# Altium schematic sheet Center Console - IO Expander.SchDoc("Center Console - IO Expander")
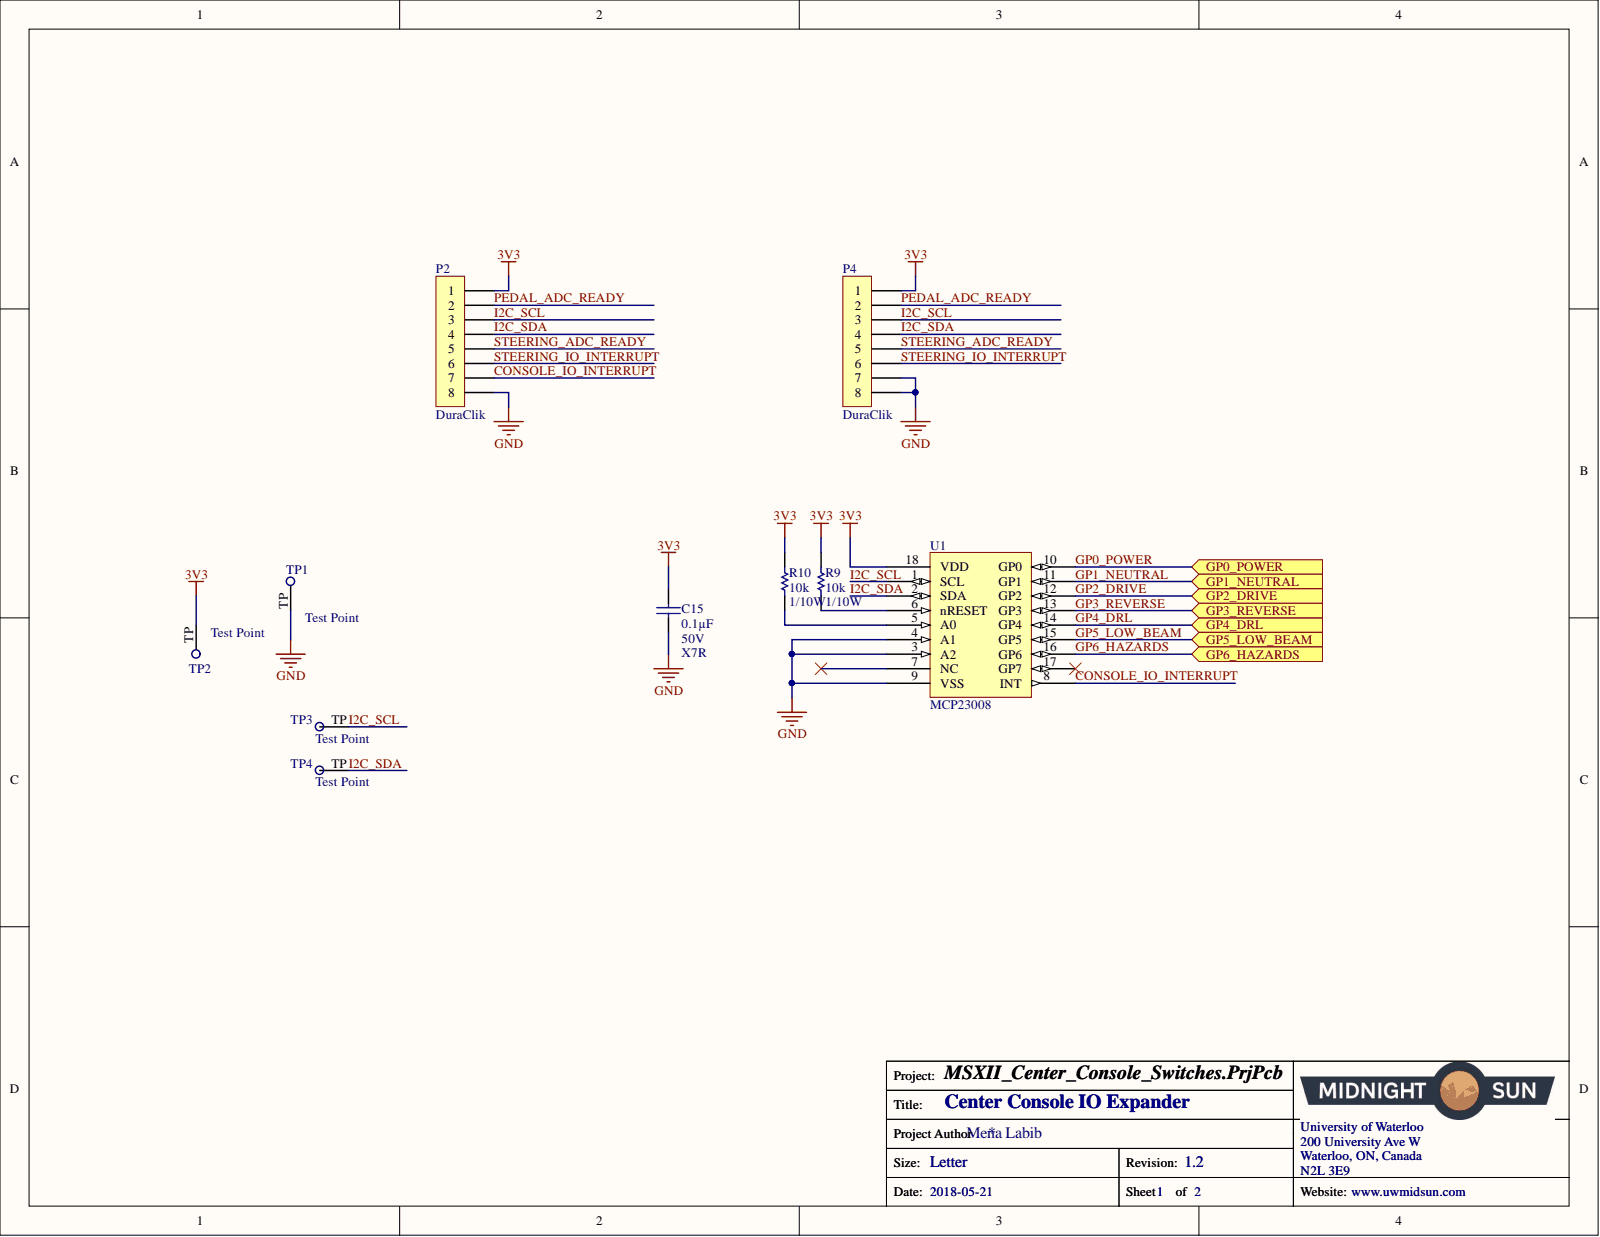
[diagram p0: Center Console - IO Expander.SchDoc]

## Parts
C15  Voltage: 50V  Temperature Coefficient: X7R  Capacitance: 0.1µF  [CAP, 0603]  (hidden comment: CAP CER 0.1UF 50V 10% X7R 0603)
P2  Series: DuraClik  [CONN, 8POS Dura-Clik]  (hidden comment: CONN 8POS DURA-CLIK 0.079")
P4  Series: DuraClik  [CONN, 8POS Dura-Clik]  (hidden comment: CONN 8POS DURA-CLIK 0.079")
R9  Resistance: 10k  Power: 1/10W  [RES, 0603]  (hidden comment: RES 10K OHM 1% 1/10W 0603)
R10  Resistance: 10k  Power: 1/10W  [RES, 0603]  (hidden comment: RES 10K OHM 1% 1/10W 0603)
TP1  Test Point  [Test Point]  (no BOM)
TP2  Test Point  [Test Point]  (no BOM)
TP3  Test Point  [Test Point]  (no BOM)
TP4  Test Point  [Test Point]  (no BOM)
U1  MCP23008  [IC 18SOIC]

## Ports
GP0_POWER, GP1_NEUTRAL, GP2_DRIVE, GP3_REVERSE, GP4_DRL, GP5_LOW_BEAM, GP6_HAZARDS

## Nets
3V3: C15.1 P2.1 P4.1 R9.1 R10.1 TP2.TP U1.18(VDD)
GND: C15.2 P2.8 P4.7 P4.8 TP1.TP U1.3(A2) U1.4(A1) U1.9(VSS)
CONSOLE_IO_INTERRUPT: P2.7 U1.8(INT)
GP0_POWER: U1.10(GP0) ; port GP0_POWER
GP1_NEUTRAL: U1.11(GP1) ; port GP1_NEUTRAL
GP2_DRIVE: U1.12(GP2) ; port GP2_DRIVE
GP3_REVERSE: U1.13(GP3) ; port GP3_REVERSE
GP4_DRL: U1.14(GP4) ; port GP4_DRL
GP5_LOW_BEAM: U1.15(GP5) ; port GP5_LOW_BEAM
GP6_HAZARDS: U1.16(GP6) ; port GP6_HAZARDS
I2C_SCL: P2.3 P4.3 TP3.TP U1.1(SCL)
I2C_SDA: P2.4 P4.4 TP4.TP U1.2(SDA)
PEDAL_ADC_READY: P2.2 P4.2
STEERING_ADC_READY: P2.5 P4.5
STEERING_IO_INTERRUPT: P2.6 P4.6
NetR9_2: R9.2 U1.6(nRESET)
NetR10_2: R10.2 U1.5(A0)

Not connected: U1 7(NC) 17(GP7)

## Library data of the parts
C15 = CAP CER 0.1UF 50V 10% X7R 0603: 0.10µF ±10% 50V Ceramic Capacitor X7R 0603 (1608 Metric); Manufacturer Part Number 1: 06035C104KAT2A; Manufacturer 1: AVX Corporation; Tolerance: ±10%; Thickness (Max): 0.035" (0.90mm); Supplier Part Number 1: 478-5052-1-ND; Supplier 1: Digi-Key; Size / Dimension: 0.063" L x 0.032" W (1.60mm x 0.81mm); Ratings: -; Part Status: Active; Packaging: Cut Tape (CT); Package / Case: 0603 (1608 Metric); Operating Temperature: -55°C ~ 125°C; Mounting Type: Surface Mount, MLCC; Lead Style: -; Lead Spacing: -; Height - Seated (Max): -; ComponentLink1URL: http://datasheets.avx.com/X7RDielectric.pdf; ComponentLink1Description: http://datasheets.avx.com/X7RDielectric.pdf; Component Kind: Standard; Library Name: Schematic Diagrams.SchLib
P2 P4 = CONN 8POS DURA-CLIK 0.079": 8 Positions Header Connector 0.079" (2.00mm) Surface Mount Tin; Manufacturer Part Number 1: 5600200820; Manufacturer 1: Molex, LLC; Style: Board to Cable/Wire; Shrouding: Shrouded - 4 Wall; Number of Positions Loaded: All; Number of Positions: 8; Mated Stacking Heights: 9.3mm; Voltage: -; Termination: Solder; Supplier Part Number 1: WM10868CT-ND; Supplier 1: Digi-Key; Row Spacing - Mating: -; Pitch - Mating: 0.079" (2.00mm); Part Status: Active; Packaging: Cut Tape (CT); Overall Contact Length: -; Operating Temperature: -; Number of Rows: 1; Mounting Type: Surface Mount; Material Flammability Rating: -; Insulation Material: Polyamide (PA), Nylon; Insulation Height: 0.315" (8.00mm); Insulation Color: Natural; Ingress Protection: -; Fastening Type: Latch Lock; Current Rating: -; Contact Type: Male Pin; Contact Shape: Square; Contact Material: Copper Alloy; Contact Length - Post: -; Contact Length - Mating: -; Contact Finish Thickness - Post: -; Contact Finish Thickness - Mating: -; Contact Finish - Post: Tin; Contact Finish - Mating: Tin; Connector Type: Header; ComponentLink1URL: http://www.molex.com/pdm_docs/sd/5600200820_sd.pdf; ComponentLink1Description: http://www.molex.com/pdm_docs/sd/5600200820_sd.pdf; Component Kind: Standard; Library Name: Schematic Diagrams.SchLib
R9 R10 = RES 10K OHM 1% 1/10W 0603: RES SMD 10K OHM 1% 1/10W 0603; Manufacturer Part Number 1: RC0603FR-0710KL; Manufacturer 1: Yageo; Temperature Coefficient: ±100ppm/°C; Tolerance: ±1%; Supplier Part Number 1: 311-10.0KHRCT-ND; Supplier Device Package: 0603; Supplier 1: Digi-Key; Size / Dimension: 0.063" L x 0.031" W (1.60mm x 0.80mm); Part Status: Active; Packaging: Cut Tape (CT); Package / Case: 0603 (1608 Metric); Operating Temperature: -55°C ~ 155°C; Height - Seated (Max): 0.022" (0.55mm); Failure Rate: -; Composition: Thick Film; ComponentLink1URL: http://www.yageo.com/documents/recent/PYu-RC_Group_51_RoHS_L_7.pdf; ComponentLink1Description: http://www.yageo.com/documents/recent/PYu-RC_Group_51_RoHS_L_7.pdf; Component Kind: Standard; Library Name: Schematic Diagrams.SchLib
U1 = IC I/O EXPANDER I2C 8B 18SOIC: MCP23008 I/O Expander 8B+1Int 18P SOIC; Manufacturer Part Number: MCP23008T-E/SO; Manufacturer: Microchip Technology; Voltage - Supply: 1.8 V ~ 5.5 V; Output Type: Push-Pull; Number of I/O: 8; Interrupt Output: Yes; Interface: I²C; Current - Output Source/Sink: 25mA; Supplier Part Number 1: MCP23008T-E/SOCT-ND; Supplier Device Package: 18-SOIC; Supplier 1: Digi-Key; Stock 1: 5607; RoHS: RoHS Compliant; Pricing 1: 1=1.62, 25=1.3548, 100=1.2431 (CAD); Part Status: Active; Packaging: Cut Tape (CT); Package / Case: 18-SOIC (0.295", 7.50mm Width); Operating Temperature: -40°C ~ 125°C; Mounting Type: Surface Mount; Features: POR; ComponentLink1URL: http://www.microchip.com/mymicrochip/filehandler.aspx?ddocname=en021920; ComponentLink1Description: http://www.microchip.com/mymicrochip/filehandler.aspx?ddocname=en021920; Component Kind: Standard; Category: Integrated Circuits (ICs); Library Name: Schematic Diagrams.SchLib
TP1-TP4 = Test Point: Library Name: Schematic Diagrams.SchLib
